# Altium schematic sheet AD8367.SchDoc
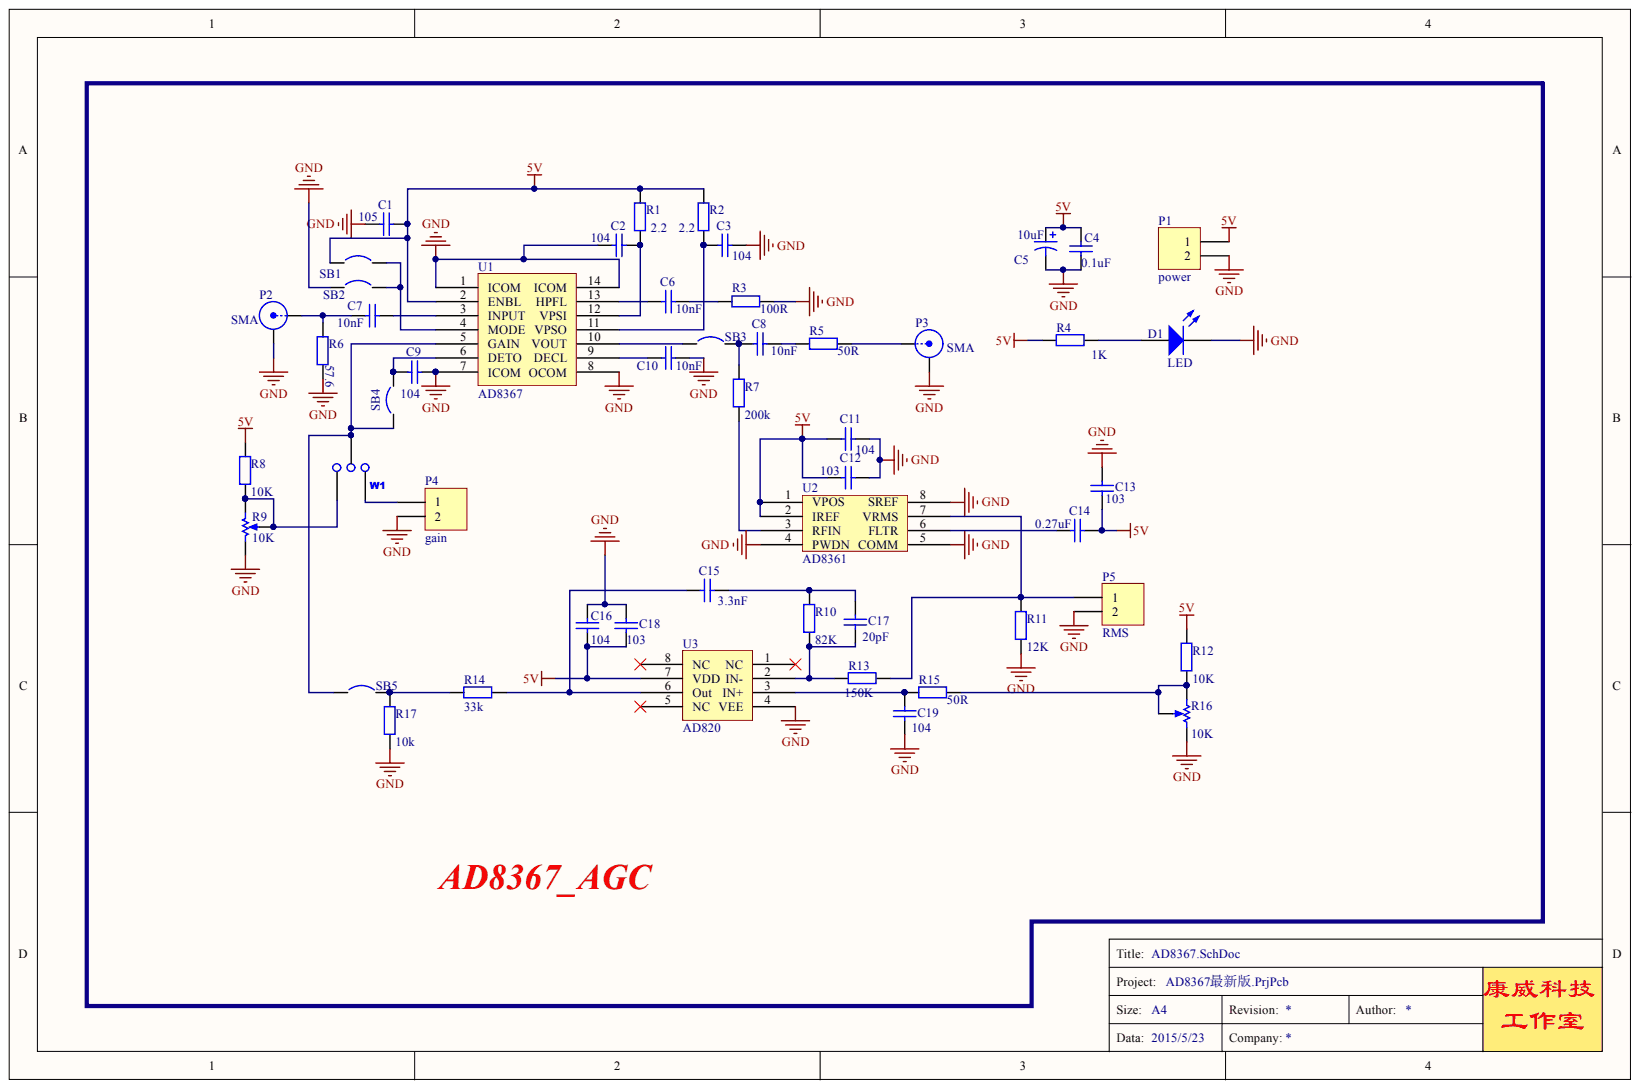
[diagram p0: AD8367.SchDoc]

## Parts
C1  value 105  [0805-cap]
C2  value 104  [0805-cap]
C3  value 104  [0805-cap]
C4  value 0.1uF  [0805-cap]
C5  value 10uF  [TAN_107]
C6  value 10nF  [0805-cap]
C7  value 10nF  [0805-cap]
C8  value 10nF  [0805-cap]
C9  value 104  [0603-CAP]
C10  value 10nF  [0805-cap]
C11  value 104  [0805-cap]
C12  value 103  [0805-cap]
C13  value 103  [0805-cap]
C14  value 0.27uF  [0805-cap]
C15  value 3.3nF  [0805-cap]
C16  value 104  [0805-cap]
C17  value 20pF  [0805-cap]
C18  value 103  [0805-cap]
C19  value 104  [0805-cap]
D1  LED  [0805-led]
P1  power  [接头x2]
P2  SMA  [SM]
P3  SMA  [SM]
P4  gain  [SIP2]
P5  RMS  [SIP2]
R1  value 2.2  [0805_r]
R2  value 2.2  [0805_r]
R3  value 100R  [0805_r]
R4  value 1K  [0805_r]
R5  value 50R  [0805_r]
R6  value 57.6  [0805_r]
R7  value 200k  [0805_r]
R8  value 10K  [0805_r]
R9  value 10K  [精密电位器]
R10  value 82K  [0805_r]
R11  value 12K  [0805_r]
R12  value 10K  [0805_r]
R13  value 150K  [0805_r]
R14  value 33k  [0805_r]
R15  value 50R  [0805_r]
R16  value 10K  [精密电位器]
R17  value 10k  [0805_r]
SB1  Circuit Breaker  [CONECT]  (value not drawn)
SB2  Circuit Breaker  [CONECT]  (value not drawn)
SB3  Circuit Breaker  [CONECT]  (value not drawn)
SB4  Circuit Breaker  [CONECT]  (value not drawn)
SB5  Circuit Breaker  [CONECT]  (value not drawn)
U1  AD8367  [AD8367]
U2  AD8361  [AD8361]
U3  AD820  [sop8]
W1  key  [KEY1to2]  (value not drawn)

## Nets
5V: C1.2 C4.2 C5.1 C11.1 C12.1 C13.1 C14.2 C16.1 C18.1 P1.1 R1.1 R2.1 R4.1 R8.2 R12.2 SB1.1 U1.2(ENBL) U2.1(VPOS) U2.2(IREF) U3.7(VDD)
GND: C1.1 C2.1 C3.2 C4.1 C5.2 C9.2 C10.1 C11.2 C12.2 C13.2 C16.2 C18.2 C19.1 D1.2 P1.2 P2.2 P3.2 P4.2 P5.2 R3.2 R6.1 R9.2 R11.1 R16.2 R17.2 SB2.1 U1.1(ICOM) U1.7(ICOM) U1.8(OCOM) U1.14(ICOM) U2.4(PWDN) U2.5(COMM) U2.8(SREF) U3.4(VEE)
NetC2_2: C2.2 R1.2 U1.12(VPSI)
NetC3_1: C3.1 R2.2 U1.11(VPSO)
NetC6_1: C6.1 R3.1
NetC6_2: C6.2 U1.13(HPFL)
NetC7_1: C7.1 U1.3(INPUT)
NetC7_2: C7.2 P2.1 R6.2
NetC8_1: C8.1 R5.2
NetC8_2: C8.2 R7.1 SB3.2
NetC9_1: C9.1 SB4.2 U1.6(DETO)
NetC10_2: C10.2 U1.9(DECL)
NetC14_1: C14.1 U2.6(FLTR)
NetC15_1: C15.1 R14.1 U3.6(Out)
NetC15_2: C15.2 C17.1 R10.2
NetC17_2: C17.2 R10.1 R13.1 U3.2(IN-)
NetC19_2: C19.2 R15.2 U3.3(IN+)
NetD1_1: D1.1 R4.2
NetP3_1: P3.1 R5.1
NetP4_1: P4.1 W1.3
NetP5_1: P5.1 R11.2 R13.2 U2.7(VRMS)
NetR7_2: R7.2 U2.3(RFIN)
NetR8_1: R8.1 R9.1 R9.3 W1.1
NetR12_1: R12.1 R15.1 R16.1 R16.3
NetR14_2: R14.2 R17.1 SB5.2
NetSB1_2: SB1.2 SB2.2 U1.4(MODE)
NetSB3_1: SB3.1 U1.10(VOUT)
NetSB4_1: SB4.1 SB5.1 U1.5(GAIN) W1.2

Not connected: U3 1(NC) 5(NC) 8(NC)

## Library data of the parts
C1-C4 C6-C19 = Cap: Capacitor; Component Kind: Standard; LatestRevisionDate: 17-Jul-2002; LatestRevisionNote: Re-released for DXP Platform.; Library Name: Miscellaneous Devices.IntLib; PackageDescription: Radial Cap, Thru-Hole; 2 Leads; 0.3 in Pin Spacing; PackageReference: RAD-0.3; Published: 8-Jun-2000; Signal Integrity: Cap; Simulation: CAP; Publisher: Altium Limited
C5 = Cap Pol1: Polarized Capacitor (Radial); Component Kind: Standard; LatestRevisionDate: 17-Jul-2002; LatestRevisionNote: Re-released for DXP Platform.; Library Name: Miscellaneous Devices.IntLib; PackageDescription: Polarized Capacitor (Radial); 2 Leads; PackageReference: RB7.6-15; Published: 8-Jun-2000; Signal Integrity: Cap Pol; Simulation: CAP; Publisher: Altium Limited
P1 P4 P5 = Header 2: Header, 2-Pin; Component Kind: Standard; LatestRevisionDate: 17-Jul-2002; LatestRevisionNote: Re-released for DXP Platform.; Signal Integrity: Connector; Library Name: Xiaowei.SchLib; Publisher: Altium Limited
P2 P3 = BNC: BNC Elbow Connector; Component Kind: Standard; LatestRevisionDate: 17-Jul-2002; LatestRevisionNote: Re-released for DXP Platform.; Library Name: Miscellaneous Devices.SchLib; Signal Integrity: Connector; Publisher: Altium Limited
R1-R8 R10-R15 R17 = Res2: Resistor; Component Kind: Standard; LatestRevisionDate: 17-Jul-2002; LatestRevisionNote: Re-released for DXP Platform.; Library Name: Miscellaneous Devices.IntLib; PackageDescription: Axial Device, Thru-Hole; 2 Leads; 0.4 in Pin Spacing; PackageReference: AXIAL-0.4; Published: 8-Jun-2000; Signal Integrity: Res; Simulation: RESISTOR; Publisher: Altium Limited
R9 R16 = RPot: Potentiometer; Set Position: 0.5; Sim Note: Enter the Resistance value and the set point into parameter fields.; Component Kind: Standard; LatestRevisionDate: 17-Jul-2002; LatestRevisionNote: Re-released for DXP Platform.; Library Name: Miscellaneous Devices.IntLib; PackageDescription: Variable Resistor, Thru-Hole; 3 Leads; PackageReference: VR5; Published: 8-Jun-2000; Simulation: POT; Publisher: Altium Limited
SB1-SB5 = Circuit Breaker: Solder: NO; Component Kind: Standard; Description: Circuit Breaker; Library Name: MCD_TOOLS.SCHLIB; Published: 24-Mar-1999; Revision: July-2002: Re-released for DXP Platform.; Publisher: Altium Limited
U3 = OPA820: Component Kind: Standard; Library Name: Xiaowei.SchLib
W1 = W: KEY 1 to 2; Component Kind: Standard
D1 = LED: Component Kind: Standard; LatestRevisionDate: 08-Jul-2005; LatestRevisionNote: Mech Layer 1 removed.; PackageDescription: LED; 2 Leads; PackageReference: LED-0; Published: 8-Jun-2000; Library Name: Xiaowei.SchLib; Publisher: Altium Limited
U1 = AD8367: Component Kind: Standard; Library Name: Xiaowei.SchLib
U2 = AD8361: Component Kind: Standard; Library Name: Xiaowei.SchLib
